# Altium schematic sheet T-Impulse Plus.SchDoc("T-Impulse Plus")
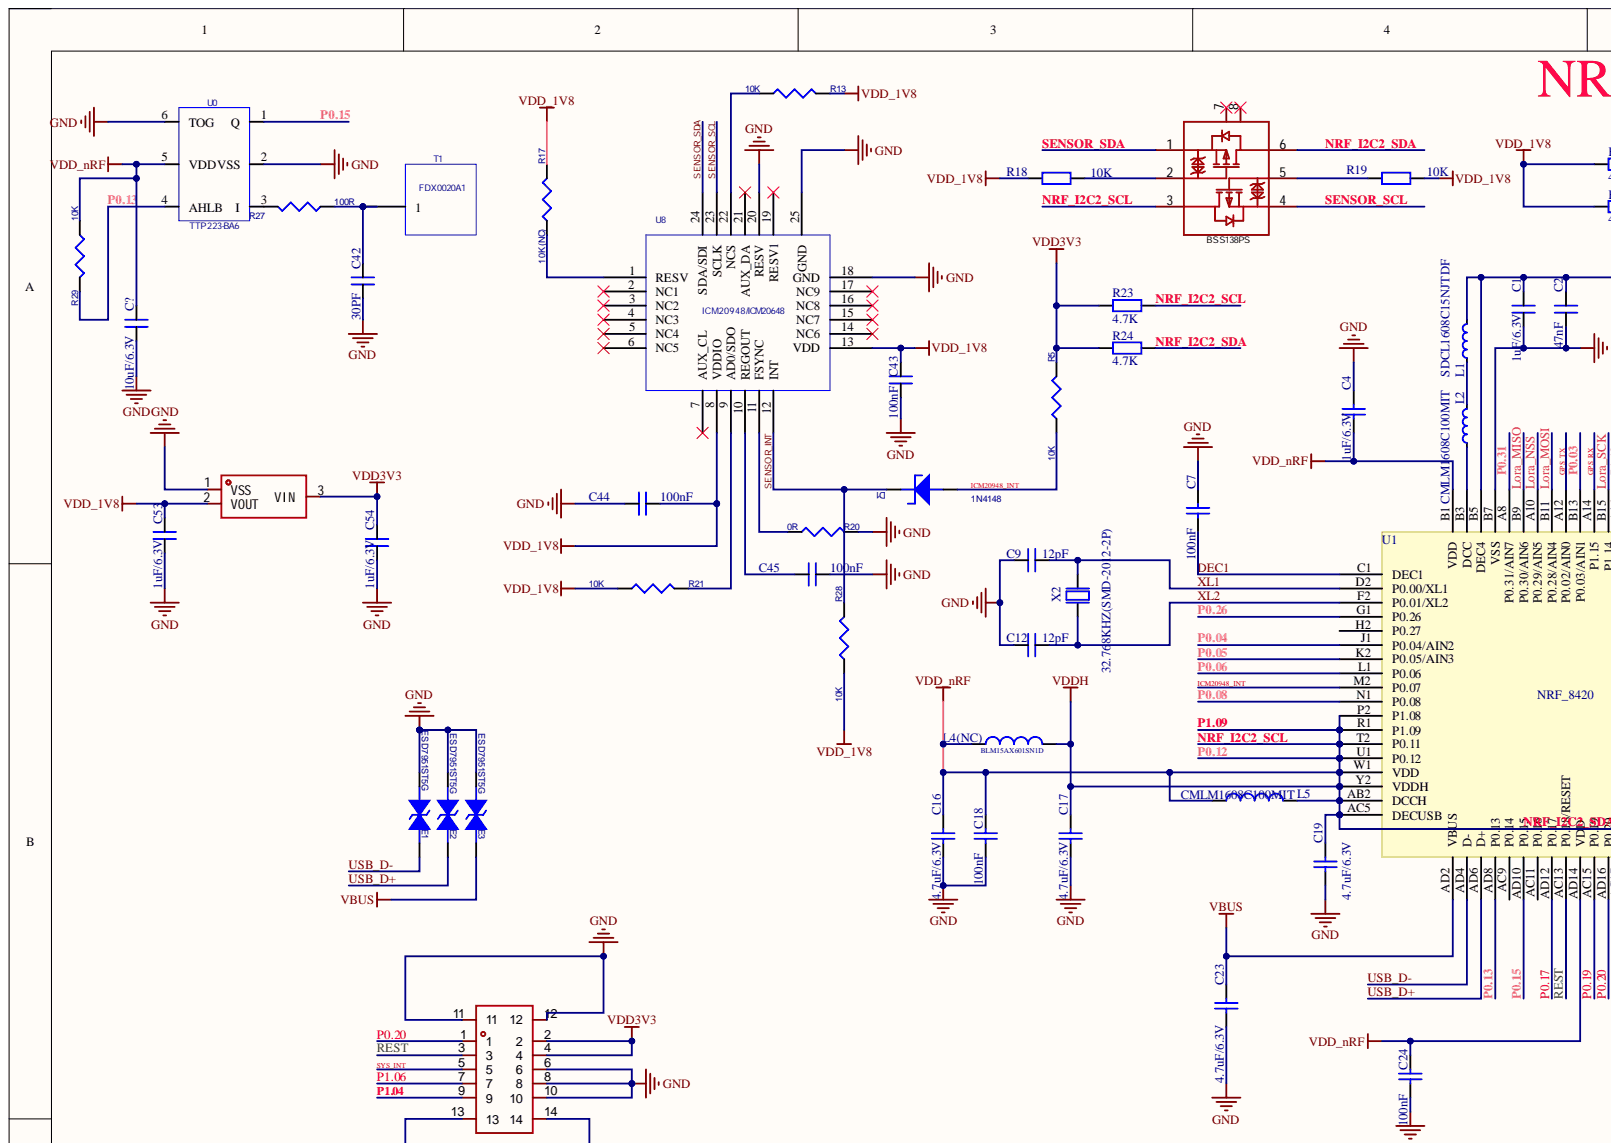
[diagram p0: T-Impulse Plus.SchDoc, top left]
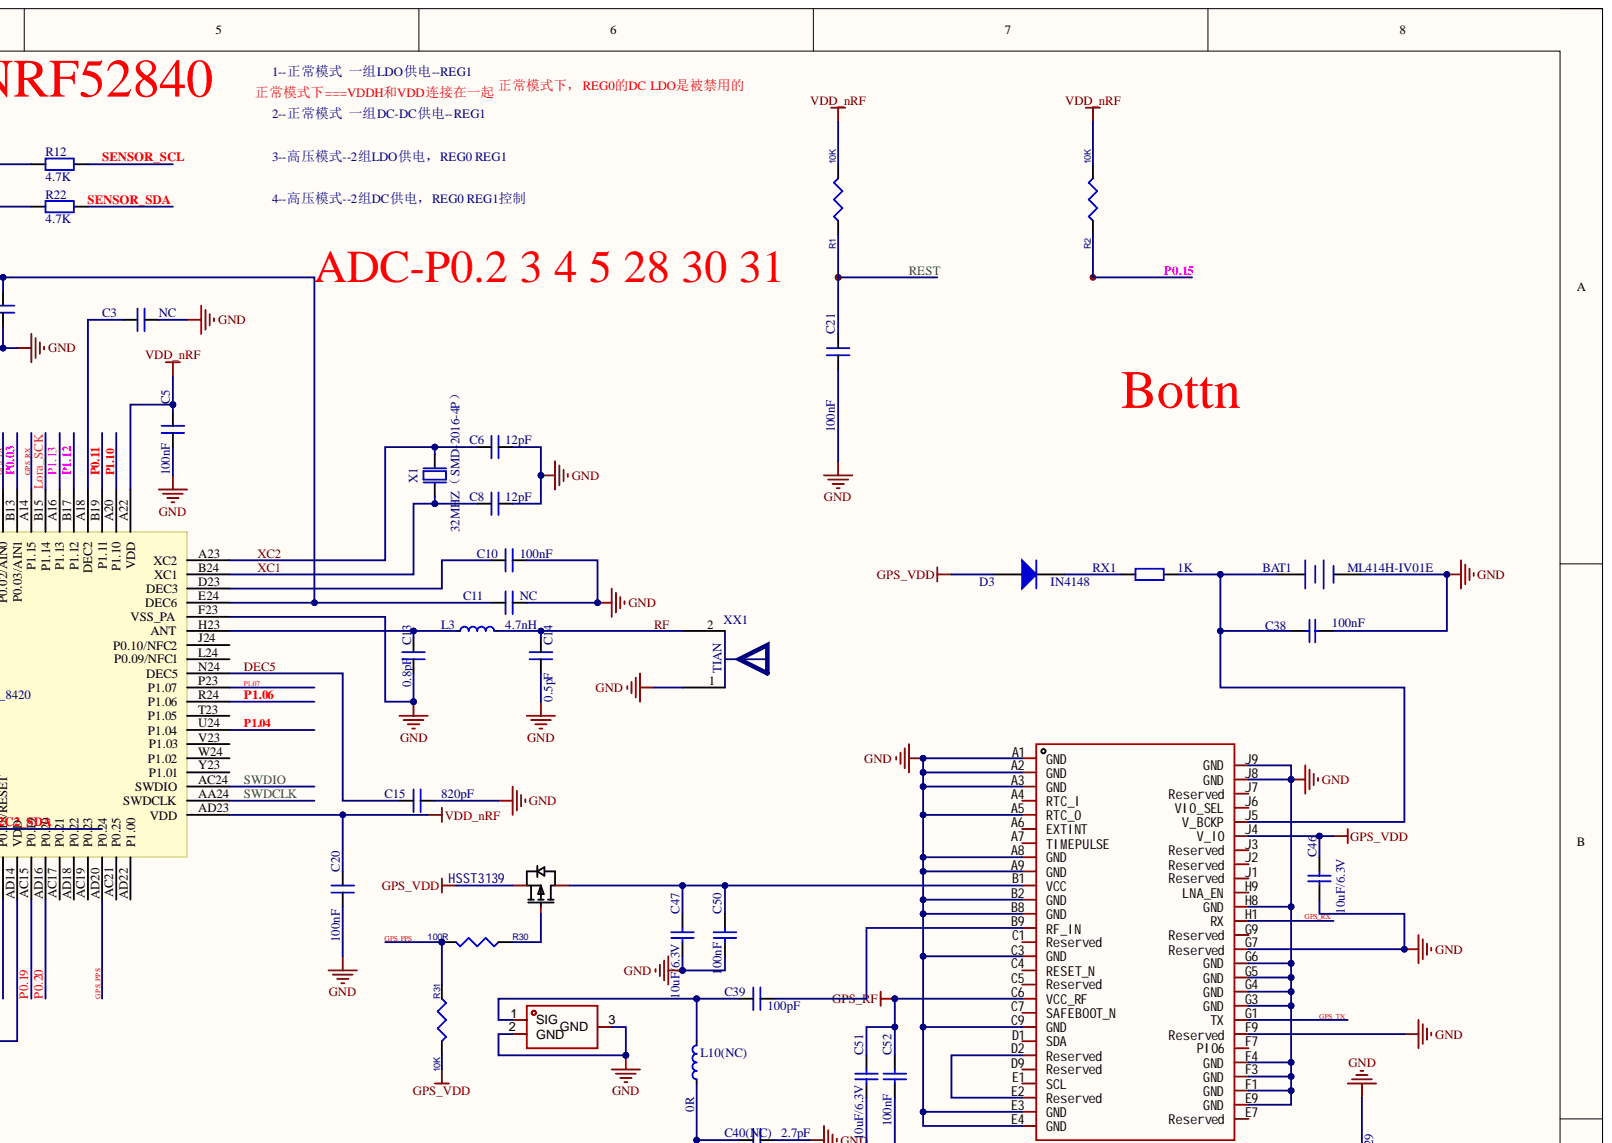
[diagram p1: T-Impulse Plus.SchDoc, top right]
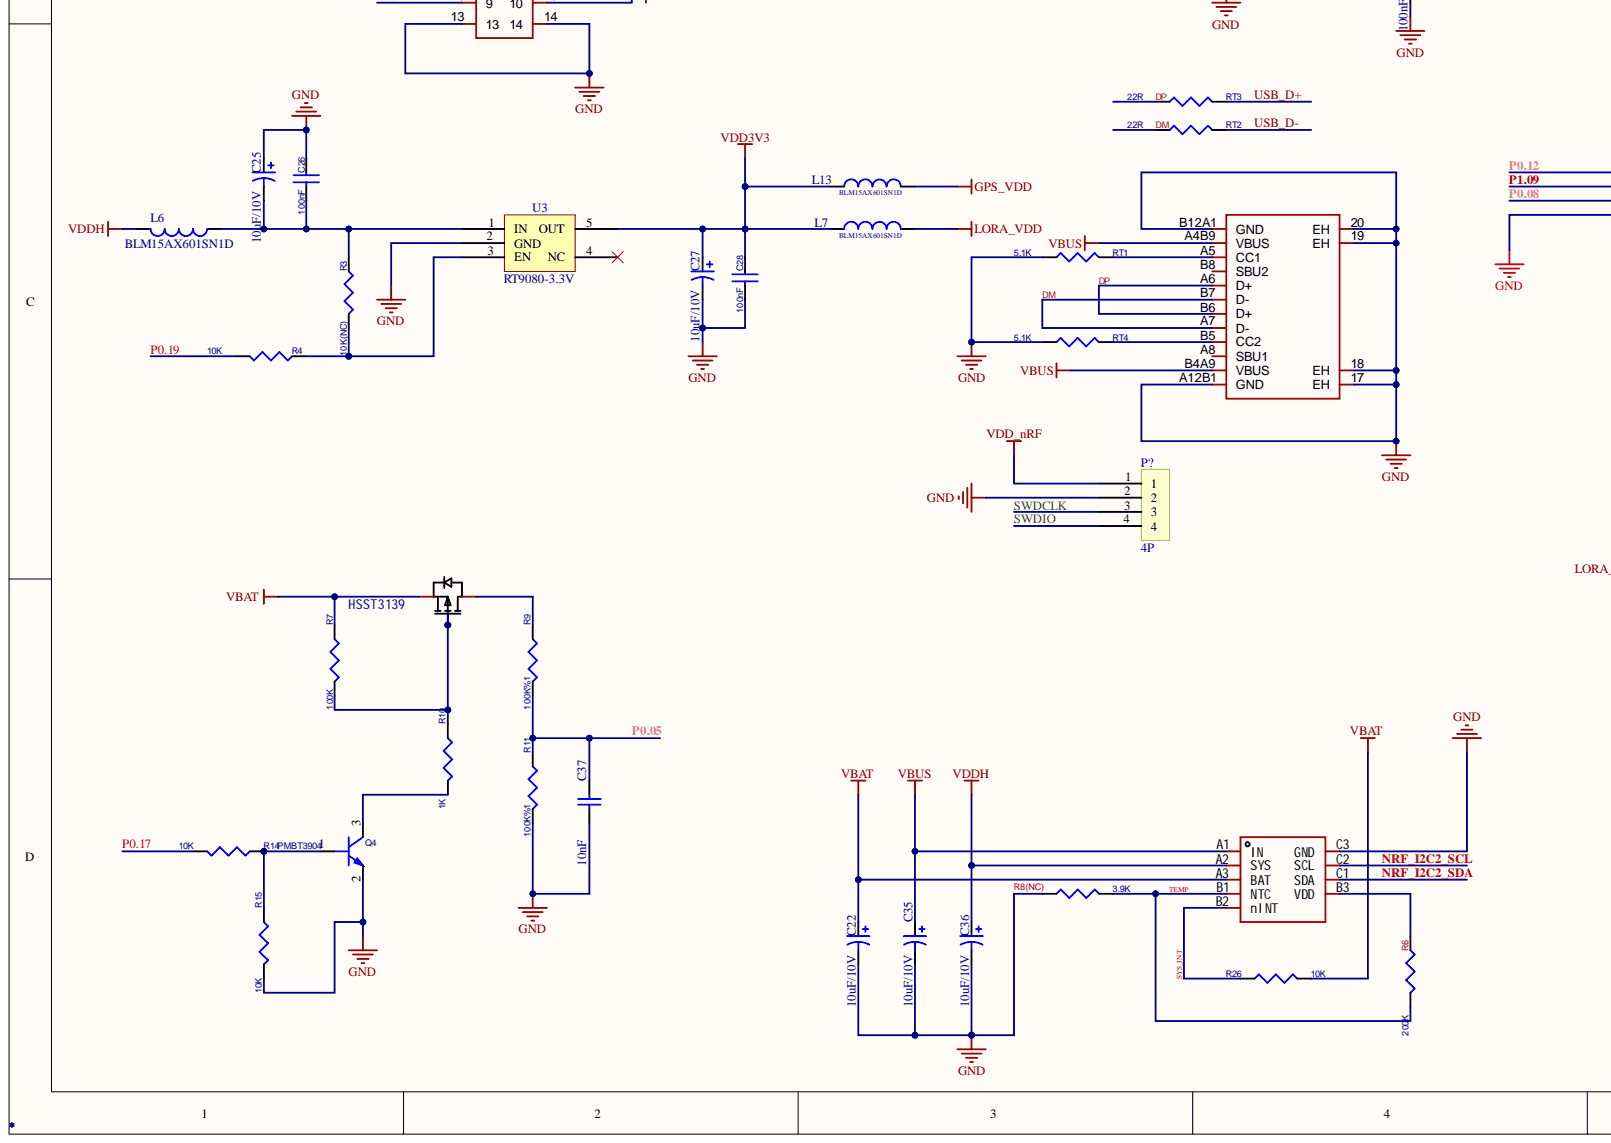
[diagram p2: T-Impulse Plus.SchDoc, bottom left]
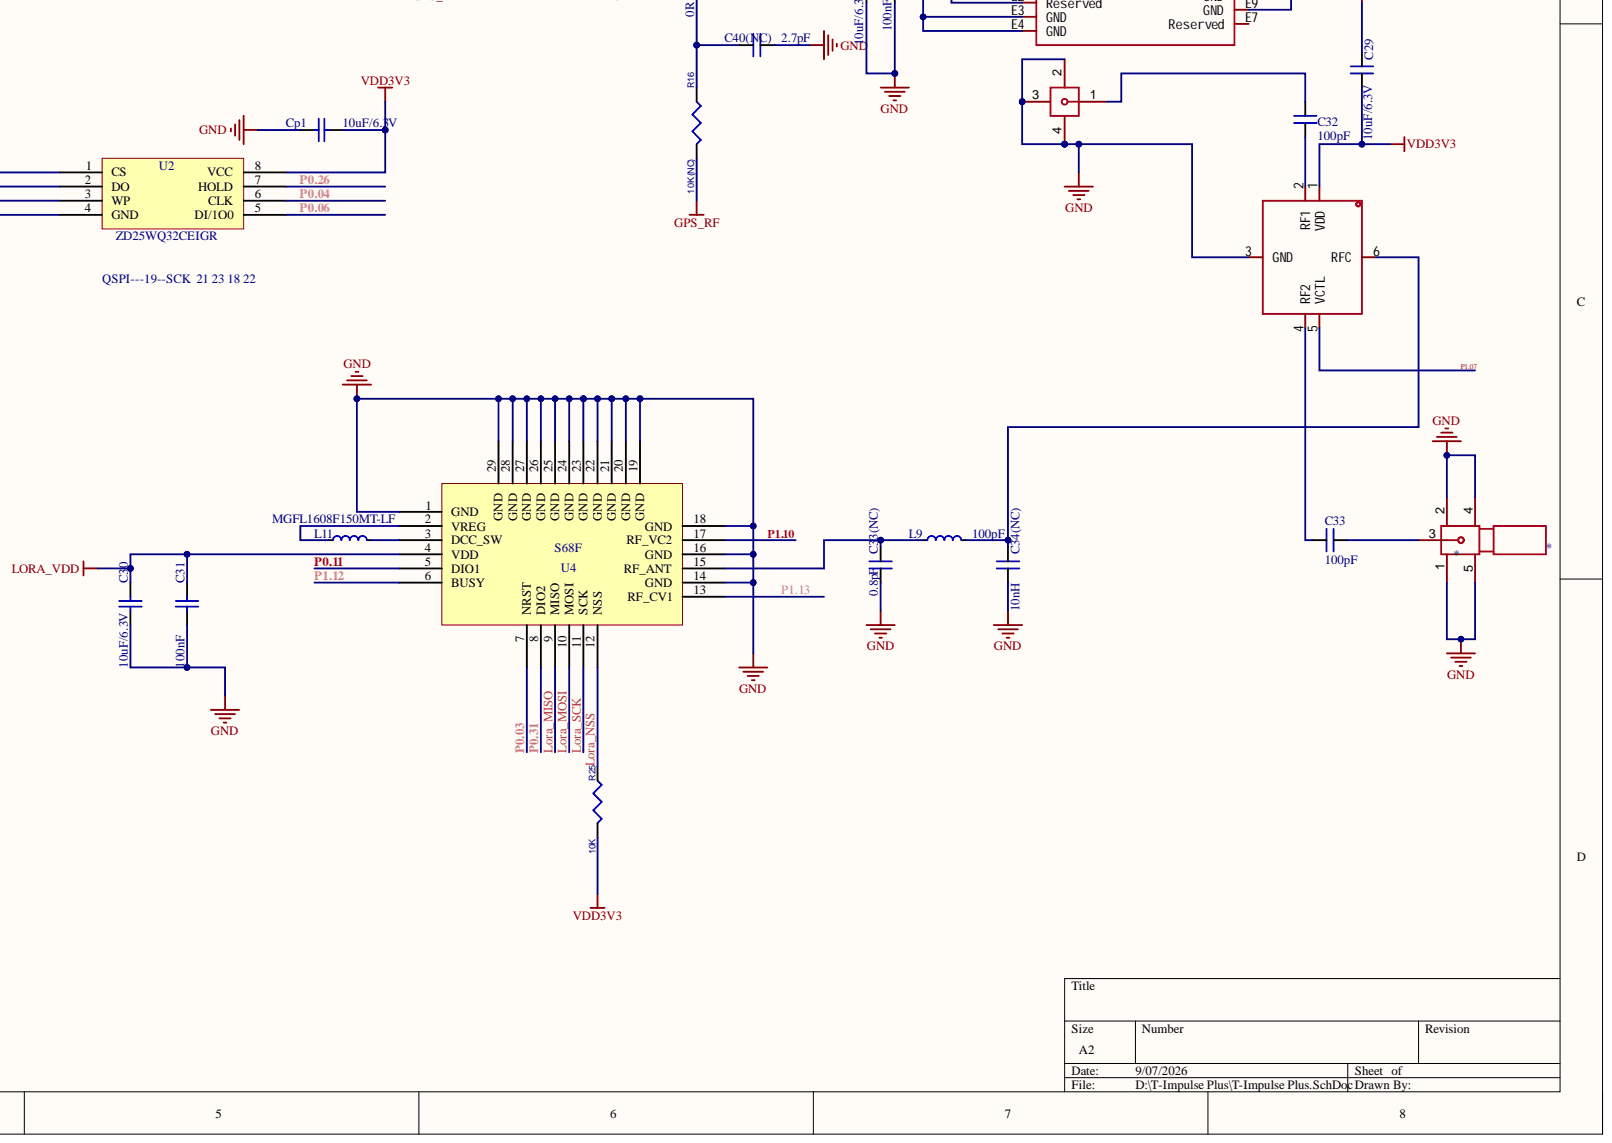
[diagram p3: T-Impulse Plus.SchDoc, bottom right]

## Parts
BAT1  ML414H-IV01E  [BAT-SMD_ML414H-IV01E]
C1  1uF/6.3V  [C0201]
C2  47nF  [C0201]
C3  NC  [C0201]
C4  1uF/6.3V  [C0201]
C5  100nF  [C0201]
C6  12pF  [C0201]
C7  100nF  [C0201]
C8  12pF  [C0201]
C9  12pF  [C0201]
C10  100nF  [C0201]
C11  NC  [C0201]
C12  12pF  [C0201]
C13  0.8pF  [C0201]
C14  0.5pF  [C0201]
C15  820pF  [C0201]
C16  4.7uF/6.3V  [C0201]
C17  4.7uF/6.3V  [C0201]
C18  100nF  [C0201]
C19  4.7uF/6.3V  [C0201]
C20  100nF  [C0201]
C21  100nF  [C0201]
C22  10uF/10V  [LC-0402_C]
C23  4.7uF/6.3V  [C0201]
C24  100nF  [C0201]
C25  10uF/10V  [LC-0402_C]
C26  100nF  [C0201]
C27  10uF/10V  [LC-0402_C]
C28  100nF  [C0201]
C29  10uF/6.3V  [LC-0402_C]
C30  10uF/6.3V  [LC-0402_C]
C31  100nF  [C0201]
C32  100pF  [C0201]
C33  100pF  [C0201]
C33(NC)  0.8pF  [C0201]
C34(NC)  10nH  [C0201]
C35  10uF/10V  [LC-0402_C]
C36  10uF/10V  [LC-0402_C]
C37  10nF  [C0201]
C38  100nF  [C0201]
C39  100pF  [C0201]
C40(NC)  2.7pF  [C0201]
C42  30PF  [C0201]
C43  100nF  [C0201]
C44  100nF  [C0201]
C45  100nF  [C0201]
C46  10uF/6.3V  [LC-0402_C]
C47  10uF/6.3V  [LC-0402_C]
C50  100nF  [C0201]
C51  10uF/6.3V  [LC-0402_C]
C52  100nF  [C0201]
C53  1uF/6.3V  [C0201]
C54  1uF/6.3V  [C0201]
C?  10uF/6.3V  [LC-0402_C]
CN?  BTB0.408-10PLBDR-M41  [CONN-SMD_10P-P0.40_XUNPU_BTB0.408-10PLBDR-M41]  (value not drawn)
Cp1  10uF/6.3V  [LC-0402_C]
D1  1N4148  [SOD-523_L1.2-W0.8-LS1.6-RD]
D3  IN4148  [SOD-323_L1.8-W1.3-LS2.5-RD]
E1  ESD7951ST5G  [DFN1006-2L_L1.0-W0.6-P0.70-BI]
E2  ESD7951ST5G  [DFN1006-2L_L1.0-W0.6-P0.70-BI]
E3  ESD7951ST5G  [DFN1006-2L_L1.0-W0.6-P0.70-BI]
L1  SDCL1608C15NJTDF  [LC-0402_L]
L2  CMLM1608C100MIT  [LC-0603_L]
L3  4.7nH  [L0201]
L4(NC)  BLM15AX601SN1D  [LC-0402_L]
L5  CMLM1608C100MIT  [LC-0603_L]
L6  BLM15AX601SN1D  [LC-0402_L]
L7  BLM15AX601SN1D  [LC-0402_L]
L9  100pF  [L0201]
L10(NC)  0R  [L0201]
L11  MGFL1608F150MT-LF  [LC-0603_L]
L13  BLM15AX601SN1D  [LC-0402_L]
P?  4P  [HDR-TH_4P-P2.54-V]
Q1  HSST3139  [SOT-523-3_L1.6-W0.8-P1.00-LS1.6-BR]
Q2  HSST3139  [SOT-523-3_L1.6-W0.8-P1.00-LS1.6-BR]
Q4  PMBT3904  [SOT-523-3_L1.6-W0.8-P1.00-LS1.6-BR]
R1  10K  [R0201]
R2  10K  [R0201]
R3  10K(NC)  [R0201]
R4  10K  [R0201]
R5  10K  [R0201]
R6  200K  [R0201]
R7  100K  [R0201]
R8(NC)  3.9K  [R0201]
R9  100K%1  [R0201]
R10  1K  [R0201]
R11  100K%1  [R0201]
R12  4.7K  [R0201]
R13  10K  [R0201]
R14  10K  [R0201]
R15  10K  [R0201]
R16  10K(NC)  [R0201]
R17  10K(NC)  [R0201]
R18  10K  [R0201]
R19  10K  [R0201]
R20  0R  [R0201]
R21  10K  [R0201]
R22  4.7K  [R0201]
R23  4.7K  [R0201]
R24  4.7K  [R0201]
R25  10K  [R0201]
R26  10K  [R0201]
R27  100R  [R0201]
R28  10K  [R0201]
R29  10K  [R0201]
R30  100R  [R0201]
R31  10K  [R0201]
RF  BWIPX-1-001E  [IPEX-SMD_4P-L1.7-W1.7-LS2.0_X-RF-3-Z21.25]  (value not drawn)
RF1  (no value)  [SMA-SMD_BWMMCX-JEF]
RF?  ZX-RF-3-Z21.25  [IPEX-SMD_4P-L1.7-W1.7-LS2.0_X-RF-3-Z21.25]  (value not drawn)
RT1  5.1K  [R0201]
RT2  22R  [R0201]
RT3  22R  [R0201]
RT4  5.1K  [R0201]
RX1  1K  [R0201]
T1  FDX0020A1?  value FDX0020A1  [1PIN_PAD]
U0  TTP223  value TTP223-BA6  [SOT26]
U1  NRF_8420  [AQFN50P700X700X85_HS-74]
U2  ZD25WQ32CEIGR  [USON-8_2X3X0.5P]
U3  RT9080-3.3V  [LC-SOT-23-5]
U4  S68F  [S62F]
U6  MIA-M10Q-00B  [LGA-53_L4.5-W4.5-R9-C9-P0.50-TL_MIA-M10Q]  (value not drawn)
U7  BSS138PS  [SOT-23-6_L2.9-W1.6-P0.95-LS2.8-BR]
U8  ICM20948/ICM20648  [QFN24]
U9  XC6206P182MR  [SOT-23-3_L3.0-W1.7-P0.95-LS2.9-BR]  (value not drawn)
U10  SGM41562BXG/TR  [DSBGA-9_L1.5-W1.5-R3-C3-P0.50-BL]  (value not drawn)
U11  SKY13453-385LF  [QFN-6_L1.0-W1.0-P0.50-LS1.0-BL]  (value not drawn)
U?  TYPEC-700-ARP16X7  [TYPE-C-SMD_TYPEC-700-ARP16X711]  (value not drawn)
X1  32MHZ（SMD-2016-4P）  [CRYSTAL-SMD_4P-L2.0-W1.6-BL]
X2  32.768KHZ(SMD-2012-2P)  [CRYSTAL-SMD_L1.7-W1.1]
XX1  TIAN  [0A_C09]

## Nets
GND: BAT1.2 C1.2 C2.2 C3.2 C4.1 C5.1 C6.2 C7.1 C8.2 C9.1 C10.2 C11.2 C12.1 C13.1 C14.1 C15.1 C16.2 C17.2 C18.2 C19.2 C20.2 C21.2 C22.2 C23.2 C24.2 C25.1 C26.1 C27.2 C28.2 C29.2 C30.2 C31.2 C33(NC).1 C34(NC).1 C35.2 C36.2 C37.2 C38.2 C40(NC).2 C42.1 C43.1 C44.1 C45.2 C46.2 C47.2 C50.1 C51.2 C52.2 C53.1 C54.1 C?.1 CN?.6 CN?.8 CN?.10 CN?.11 CN?.12 CN?.13 CN?.14 Cp1.1 E1.2 E2.2 E3.2 P?.2 Q4.2 R8(NC).1 R11.2 R15.2 R20.2 RF.2 RF.3 RF1.1 RF1.2 RF1.4 RF1.5 RF?.2 RF?.3 RF?.4 RT1.1 RT4.1 U0.2(VSS) U0.6(TOG) U1.B7(VSS) U1.F23(VSS_PA) U2.4(GND) U3.2(GND) U4.1(GND) U4.14(GND) U4.16(GND) U4.18(GND) U4.19(GND) U4.20(GND) U4.21(GND) U4.22(GND) U4.23(GND) U4.24(GND) U4.25(GND) U4.26(GND) U4.27(GND) U4.28(GND) U4.29(GND) U6.A1(GND) U6.A2(GND) U6.A3(GND) U6.A5(RTC_O) U6.A8(GND) U6.A9(GND) U6.B2(GND) U6.B8(GND) U6.C3(GND) U6.C9(GND) U6.E3(GND) U6.E4(GND) U6.E9(GND) U6.F1(GND) U6.F3(GND) U6.F4(GND) U6.F9(Reserved) U6.G3(GND) U6.G4(GND) U6.G5(GND) U6.G6(GND) U6.G7(Reserved) U6.H8(GND) U6.J8(GND) U6.J9(GND) U8.18(GND) U8.20(RESV) U8.25(GND) U9.1 U10.C3(GND) U11.3(GND) U?.17(EH) U?.18(EH) U?.19(EH) U?.20(EH) U?.A12B1(GND) U?.B12A1(GND) X1.2 X1.4 XX1.1
GPS_RF: C51.1 C52.1 R16.2 U6.C6(VCC_RF)
GPS_VDD: C46.1 D3.1 L13.2 Q1.2 R31.2 U6.J4(V_IO)
LORA_VDD: C30.1 C31.1 L7.2 U4.4(VDD)
VBAT: C22.1 Q2.2 R7.1 R26.2 U10.A3(BAT)
VBUS: C23.1 C35.1 E3.1 U1.AD2(VBUS) U10.A1(IN) U?.A4B9(VBUS) U?.B4A9(VBUS)
VDD3V3: C27.1 C28.1 C29.1 C54.2 CN?.2 CN?.4 Cp1.2 L7.1 L13.1 R5.2 R23.2 R24.2 R25.2 U2.8(VCC) U3.5(OUT) U9.3 U11.1(VDD)
VDD_1V8: C43.2 C44.2 C53.2 R12.2 R13.2 R17.2 R18.1 R19.2 R21.1 R22.2 R28.1 U8.8(VDDIO) U8.13(VDD) U9.2
DEC1: C7.2 U1.C1(DEC1)
DEC5: C15.2 U1.N24(DEC5)
DM: RT2.1 U?.A7(D-) U?.B7(D-)
DP: RT3.1 U?.A6(D+) U?.B6(D+)
GPS_PPS: R30.2 R31.1 U1.AD20(P0.24)
GPS_RX: U1.A14(P1.15) U6.H1(RX)
GPS_TX: U1.A12(P0.02/AIN0) U6.G1(TX)
ICM20948_INT: D1.1 R5.1 U1.M2(P0.07)
Lora_MISO: U1.B9(P0.30/AIN6) U4.9(MISO)
Lora_MOSI: U1.B11(P0.28/AIN4) U4.10(MOSI)
Lora_NSS: R25.1 U1.A10(P0.29/AIN5) U4.12(NSS)
Lora_SCK: U1.B15(P1.14) U4.11(SCK)
NRF_I2C2_SCL: C4.2 C5.2 C16.1 C17.1 C18.1 C19.1 C20.1 C24.1 C36.1 C?.2 L4(NC).1 L4(NC).2 L5.1 L5.2 L6.2 P?.1 R1.2 R2.2 R23.1 R24.1 R29.1 U0.5(VDD) U1.A22(VDD) U1.AB2(DCCH) U1.AC5(DECUSB) U1.AD14(VDD) U1.AD23(VDD) U1.B1(VDD) U1.P2(P1.08) U1.R1(P1.09) U1.T2(P0.11) U1.U1(P0.12) U1.W1(VDD) U1.Y2(VDDH) U2.1(CS) U2.2(DO) U7.3 U7.6 U10.A2(SYS) U10.C1(SDA) U10.C2(SCL)
P0.03: U1.B13(P0.03/AIN1) U4.7(NRST)
P0.04: U1.J1(P0.04/AIN2) U2.6(CLK)
P0.05: C37.1 R9.2 R11.1 U1.K2(P0.05/AIN3)
P0.06: U1.L1(P0.06) U2.5(DI/1O0)
P0.08: U1.N1(P0.08) U2.3(WP)
P0.11: U1.B19(P1.11) U4.5(DIO1)
P0.13: R29.2 U0.4(AHLB) U1.AD8(P0.13)
P0.15: R2.1 U0.1(Q) U1.AD10(P0.15)
P0.17: R14.2 U1.AD12(P0.17)
P0.19: R4.2 U1.AC15(P0.19)
P0.20: CN?.1 U1.AD16(P0.20)
P0.26: U1.G1(P0.26) U2.7(HOLD)
P0.31: U1.A8(P0.31/AIN7) U4.8(DIO2)
P1.04: CN?.9 U1.U24(P1.04)
P1.06: CN?.7 U1.R24(P1.06)
P1.07: U1.P23(P1.07) U11.5(VCTL)
P1.10: U1.A20(P1.10) U4.17(RF_VC2)
P1.12: U1.B17(P1.12) U4.6(BUSY)
P1.13: U1.A16(P1.13) U4.13(RF_CV1)
REST: C21.1 CN?.3 R1.1 U1.AC13(P0.18/RESET)
RF: C14.2 L3.2 XX1.2
SENSOR_INT: D1.2 R28.2 U8.12(INT)
SENSOR_SCL: R12.1 U7.4 U8.23(SCLK)
SENSOR_SDA: R22.1 U7.1 U8.24(SDA/SDI)
SWDCLK: P?.3 U1.AA24(SWDCLK)
SWDIO: P?.4 U1.AC24(SWDIO)
SYS_INT: CN?.5 R26.1 U10.B2(nINT)
TEMP: R6.2 R8(NC).2 U10.B1(NTC)
USB_D+: E2.1 RT3.2 U1.AD6(D+)
USB_D-: E1.1 RT2.2 U1.AD4(D-)
XC1: C8.1 U1.B24(XC1) X1.1
XC2: C6.1 U1.A23(XC2) X1.3
XL1: C9.2 U1.D2(P0.00/XL1) X2.1
XL2: C12.2 U1.F2(P0.01/XL2) X2.2
NetBAT1_1: BAT1.1 C38.1 RX1.2 U6.J5(V_BCKP)
NetC1_1: C1.1 C2.1 C11.1 L1.2 U1.B5(DEC4) U1.E24(DEC6)
NetC3_1: C3.1 U1.A18(DEC2)
NetC10_1: C10.1 U1.D23(DEC3)
NetC13_2: C13.2 L3.1 U1.H23(ANT)
NetC25_2: C25.2 C26.2 L6.1 R3.1 U3.1(IN)
NetC32_1: C32.1 RF?.1
NetC32_2: C32.2 U11.2(RF1)
NetC33(NC)_2: C33(NC).2 L9.1 U4.15(RF_ANT)
NetC33_1: C33.1 RF1.3
NetC33_2: C33.2 U11.4(RF2)
NetC34(NC)_2: C34(NC).2 L9.2 U11.6(RFC)
NetC39_1: C39.1 L10(NC).2 RF.1
NetC39_2: C39.2 U6.B9(RF_IN)
NetC40(NC)_1: C40(NC).1 L10(NC).1 R16.1
NetC42_2: C42.2 R27.1 T1.1
NetC45_1: C45.1 U8.10(REGOUT)
NetC47_1: C47.1 C50.2 Q1.3 U6.B1(VCC)
NetD3_2: D3.2 RX1.1
NetL1_1: L1.1 L2.2
NetL2_1: L2.1 U1.B3(DCC)
NetL11_1: L11.1 U4.2(VREG)
NetL11_2: L11.2 U4.3(DCC_SW)
NetQ1_1: Q1.1 R30.1
NetQ2_1: Q2.1 R7.2 R10.1
NetQ2_3: Q2.3 R9.1
NetQ4_1: Q4.1 R14.1 R15.1
NetQ4_3: Q4.3 R10.2
NetR3_2: R3.2 R4.1 U3.3(EN)
NetR6_1: R6.1 U10.B3(VDD)
NetR13_1: R13.1 U8.22(NCS)
NetR17_1: R17.1 U8.1(RESV)
NetR18_2: R18.2 U7.2
NetR19_1: R19.1 U7.5
NetR20_1: R20.1 U8.11(FSYNC)
NetR21_2: R21.2 U8.9(AD0/SDO)
NetR27_2: R27.2 U0.3(I)
NetRT1_2: RT1.2 U?.A5(CC1)
NetRT4_2: RT4.2 U?.B5(CC2)
NetU6_D2: U6.D2(Reserved) U6.E2(Reserved)

Not connected: U1 AC9(P0.14) AC11(P0.16) AC17(P0.21) AC19(P0.23) AC21(P0.25) AD18(P0.22) AD22(P1.00) H2(P0.27) J24(P0.10/NFC2) L24(P0.09/NFC1) T23(P1.05) V23(P1.03) W24(P1.02) Y23(P1.01); U3 4(NC); U6 A4(RTC_I) A6(EXTINT) A7(TIMEPULSE) C1(Reserved) C4(RESET_N) C5(Reserved) C7(SAFEBOOT_N) D1(SDA) D9(Reserved) E1(SCL) E7(Reserved) F7(PIO6) G9(Reserved) H9(LNA_EN) J1(Reserved) J2(Reserved) J3(Reserved) J6(VIO_SEL) J7(Reserved); U7 7 8; U8 2(NC1) 3(NC2) 4(NC3) 5(NC4) 6(NC5) 7(AUX_CL) 14(NC6) 15(NC7) 16(NC8) 17(NC9) 19(RESV1) 21(AUX_DA); U? A8(SBU1) B8(SBU2)

## Library data of the parts
BAT1 = Battery: Multicell Battery; Component Kind: Standard; LatestRevisionDate: 17-Jul-2002; LatestRevisionNote: Re-released for DXP Platform.; Library Name: Miscellaneous Devices.IntLib; PackageDescription: Battery; 2 Leads; PackageReference: BAT-2; Published: 24-Mar-1999; Publisher: Altium Limited
C1-C3 C5 C6 C8-C15 C20 C21 C29 C32 C33 C33(NC) C34(NC) C39 C40(NC) C42-C45 C53 C54 C? = C: Component Kind: Standard; Library Name: 光学蓝牙.SCHLIB
C4 C7 C16-C19 C23 C24 C30 C31 C37 C38 C46 C47 C50-C52 Cp1 = Cap: Capacitor; Value: 100pF; Component Kind: Standard; LatestRevisionDate: 17-Jul-2002; LatestRevisionNote: Re-released for DXP Platform.; Library Name: K210--Crazy.SCHLIB; PackageReference: RAD-0.3; Published: 8-Jun-2000; Publisher: Altium Limited
C22 C25 C27 C35 C36 = Cap Pol3: Polarized Capacitor (Surface Mount); Value: 100pF; Component Kind: Standard; LatestRevisionDate: 17-Jul-2002; LatestRevisionNote: Re-released for DXP Platform.; Library Name: Miscellaneous Devices.IntLib; PackageReference: C0805; Published: 8-Jun-2000; Publisher: Altium Limited
C26 C28 = CAP NP: Component Kind: Standard
D1 = DIODE ZENER_4_1: room: power1; Component Kind: Standard; Library Name: 光学蓝牙.SCHLIB
D3 = Diode: Default Diode; Code_JEDEC: DO-214-AB; Component Kind: Standard; LatestRevisionDate: 17-Jul-2002; LatestRevisionNote: Re-released for DXP Platform.; Library Name: MySch.SchLib; PackageDocument: Sep-1996; PackageReference: SMC; Published: 8-Jun-2000; Publisher: Altium Limited
E1-E3 = ESD: Component Kind: Standard
L1-L3 L9 L10(NC) L11 = L0402-3n9: Inductor, 170mA, ?.1nH; Manufacturer: Murata; Manufacturer Part Number: LQP15MN3N9B02D; Part number IPS: 00000007793; Artikkelnr Noca: 33029151; Artikkelnr Simpro: N.A.; Database Table Name: Inductors$; Note: High frequency chip inductor ?%; Value: 3.9nH; Component Kind: Standard; ComponentLink1Description: Datasheet; ComponentLink2Description: Supplier link; Datasheet: http://search.murata.co.jp/Ceramy/image/img/PDF/ENG/L0075S0084LQP15M.pdf; Footprint Doc: 0402; LatestRevisionDate: 2012-09-19; Library Name: Nordic Passive DB.DbLib; Part Number: L0402-3n9; Pin Count: 2; Published: 2009-05-08; Supplier: Digi-Key; Supplier part number: 490-1131-1-ND; Publisher: Nordic Semiconductor
L4(NC) L7 L13 = INDUCTOR: Power Inductor, 4.7uH, 0.7A, 260mR DCR; Manufacturer: Taiyo Yuden; Manufacturer Part Number: CBC2518T4R7M; Part Creator: JG; XMOS Part Number: E-09-0011; Approved: NO; Approx Volume Cost ($): 0.07; DEVICE: L; FORWARD_PCB: 1; LEVEL: STD; Max DC Resistance: 0.26R; Rated Current (Saturation): 0.68A; Rated Current (Temp Rise): 0.92A; Tolerance (%): 20; VALUE: 2.0nH; Component Kind: Standard; Datasheet: http://www.yuden.co.jp/us/product/pdf/ecb2_e.pdf; Digikey Link: http://search.digikey.com/scripts/DkSearch/dksus.dll?lang=en&site=US&keywords=CBC2518T4R7M; Library Name: MySchlib.SchLib; Max Height (mm): 2
L5 L6 = Inductor: Code_IEC: 1005; Value: 10mH; Code_JEITA: 0402; Component Kind: Standard; Description: Inductor; LatestRevisionDate: 29-May-2009; LatestRevisionNote: IPC-7351 Footprint Added.; Library Name: Miscellaneous Devices.IntLib; PackageDescription: Chip Inductor; PackageReference: 0402-A; Published: 8-Jun-2000; Publisher: Altium Limited
Q1 Q2 = AO3401_C727156: Manufacturer: TWGMC(台湾迪嘉); Manufacturer Part: AO3401; Add into BOM: yes; Continuous Drain Current (Id): 4.2A; Drain Source On Resistance (RDS(on)@Vgs,Id): 65mΩ@10V,4.2A; Drain Source Voltage (Vdss): 30V; Gate Threshold Voltage (Vgs(th)@Id): 1.3V@250uA; Power Dissipation (Pd): 350mW; Type: P沟道; Component Kind: Standard; Convert to PCB: yes; Datasheet: https://atta.szlcsc.com/upload/public/pdf/source/20200827/C727156_6E081BBEEFBC6B8FEE164CB196B0AF30.pdf; Device: AO3401_C727156; Input Capacitance (Ciss@Vds): -; JLCPCB Part Class: Extended Part; LCSC Part Name: P沟道 耐压:30V 电流:4.2A; Name: AO3401; Operating Temperature: -; Reverse Transfer Capacitance (Crss@Vds): -; Supplier: LCSC; Supplier Footprint: SOT-23; Supplier Part: C727156; Symbol: AO3401_C727156; Total Gate Charge (Qg@Vgs): -
Q4 = PMBT3904_Dup1: OriginalSymbolOrigin: (0,0); ROOM: power; Component Kind: Standard
R1-R4 R6 R7 R8(NC) R9-R11 R14-R16 R25 R26 R30 R31 = R: Component Kind: Standard; Library Name: 光学蓝牙.SCHLIB
R5 R13 R17 R20 R21 R27-R29 RT1-RT4 = R_Dup1: Component Kind: Standard
R12 R22-R24 = Res2: Resistor; Component Kind: Standard; LatestRevisionDate: 2013年12月24日; LatestRevisionNote: Re-released for DXP Platform.; Library Name: MyLibrary.IntLib; Published: 2013年12月24日; Value: 4.7K
R18 R19 RX1 = Res2: Resistor; Value: 4.7K; Component Kind: Standard; LatestRevisionDate: 2013年12月24日; LatestRevisionNote: Re-released for DXP Platform.; Library Name: MyLibrary.IntLib; Published: 2013年12月24日
RF1 = BWMMCX-JEF: Component Kind: Standard; Symbol: BWMMCX-JEF
T1 = PAD: PCB DECAL: 1PIN_2.54; Source_Path: F:\芯元电子科技\硬件资料\芯元科技\TP4054\LIBRARY1.OLB; Component Kind: Standard
U0 = U_MP3202_1: MP1488/UP6003AMT6  Fixed Frequency White LED Driver; Source_Path: F:\芯元电子科技\硬件资料\芯元科技\手表1.6LCD\T-WATCH-N\T-WATCH-N.DSN; Component Kind: Standard
U2 = GD25LQ128DSIGR: Component Kind: Standard; Library Name: 885阿里云PCB_Project1.SCHLIB
U3 = AP2112K-3.3V: Component Kind: Standard; Library Name: 885阿里云PCB_Project1.SCHLIB
U6 = MIA-M10Q-00B: Manufacturer: U-BLOX; Add into BOM: yes; Component Kind: Standard; Convert to PCB: yes; Datasheet: https://atta.szlcsc.com/upload/public/pdf/source/231023/MIA-M10Q_DataSheet_UBX-22015849-df780d605b9c9d525ff5e8e745ca965b.pdf; Device: MIA-M10Q-00B; JLCPCB Part Class: Extended Part; LCSC Part Name: MIA-M10Q-00B; Manufacturer Part: MIA-M10Q-00B; Supplier: LCSC; Supplier Footprint: SMD-53P; Supplier Part: C6990279; Symbol: MIA-M10Q-00B
U7 = NTLUD3A50PZTBG: Manufacturer: onsemi(安森美); Add into BOM: yes; Component Kind: Standard; Convert to PCB: yes; Datasheet: https://atta.szlcsc.com/upload/public/pdf/source/20221020/883BCF178785C3F963DD958EAC0BBD20.pdf; Device: NTLUD3A50PZTBG; JLCPCB Part Class: Extended Part; LCSC Part Name: 双 P 沟道，?Cool 功率 MOSFET，-20V，-5.6A， 50mΩ; Manufacturer Part: NTLUD3A50PZTBG; Supplier: LCSC; Supplier Footprint: UDFN-6(2x2); Supplier Part: C894018; Symbol: NTLUD3A50PZTBG
U8 = 9250_1: Source_Path: H:\芯元科技\9250\9250.DSN; Component Kind: Standard; PCB DECAL: QFN24; Value: ICM20948/ICM20648
U9 = XC6206P182MR_C347373: Manufacturer: UMW(友台半导体); Add into BOM: yes; Dropout Voltage: 280mV@(50mA); Maximum Input Voltage: 8V; Number of Outputs: 1; Output Current: 250mA; Output Polarity: 正极; Output Type: 固定; Output Voltage: 1.8V; Power Supply Rejection Ratio (PSRR): 40dB@(1kHz); Component Kind: Standard; Convert to PCB: yes; Datasheet: https://atta.szlcsc.com/upload/public/pdf/source/20241029/DFB0998C0588718C146C8B83CE14F55A.pdf; Device: XC6206P182MR_C347373; Feature: 过流保护(OCP)；短路保护; JLCPCB Part Class: Extended Part; LCSC Part Name: 高纹波抑制率、低功耗、低压差 CMOS 降压型电压稳压器; Manufacturer Part: XC6206P182MR; Noise: -; Operating Temperature: -; PCB3D: SOT-23-3L_L2.9-W1.6-H1.1-LS2.8-P0.95; standby current: -; Supplier: LCSC; Supplier Footprint: SOT-23; Supplier Part: C347373; Symbol: XC6206P182MR_C347373
U10 = SGM41562BXG/TR: Manufacturer: SGMICRO(圣邦微); Add into BOM: yes; Component Kind: Standard; Convert to PCB: yes; Datasheet: https://atta.szlcsc.com/upload/public/pdf/source/20230206/50C8D5CD95A193ADAA885C04D891C463.pdf; Device: SGM41562BXG/TR; JLCPCB Part Class: Extended Part; LCSC Part Name: SGM41562BXG/TR; Manufacturer Part: SGM41562BXG/TR; PCB3D: DSBGA-9_L1.5-W1.5-H0.6-R3-C3-P0.50-BL; Supplier: LCSC; Supplier Footprint: WLCSP-9-B(1.52x1.52); Supplier Part: C5153801; Symbol: SGM41562BXG/TR
U11 = SKY13453-385LF: Manufacturer: SKYWORKS; Add into BOM: yes; Frequency range: 10MHz~6GHz; Insertion loss: 0.4dB; isolation: 27dB; Voltage - Supply: 2.5V~3.5V; Component Kind: Standard; Convert to PCB: yes; Datasheet: https://atta.szlcsc.com/upload/public/pdf/source/20180126/C150878_15169561930931309364.pdf; Device: SKY13453-385LF; JLCPCB Part Class: Extended Part; LCSC Part Name: 0.01至6.0GHz单控制SPDT射频开关; Manufacturer Part: SKY13453-385LF; operating temperature: -40℃~+90℃; PCB3D: QFN-6_L1.0-W1.0-H0.5_P0.50; Supplier: LCSC; Supplier Footprint: QFN-6(1x1); Supplier Part: C150878; Symbol: SKY13453-385LF
X1 = XTAL_SMD2016_32MHz_8pF_Kyocera: XTAL SMD 2016, 32MHz, Cl=8pF, Tot: ?0ppm; Manufacturer Part Number: CX2016DB32000D0WZRC1; Part number IPS: 00000007827; Artikkelnr Noca: 22010022; Artikkelnr Simpro: N.A.; Database Table Name: Crystal_Osc$; Note: XTAL SMD 32.000MHz; Component Kind: Standard; Datasheet: http://media.digikey.com/pdf/Data%20Sheets/AVX%20PDFs/CX2016DB32000D0WZRC1_Spec.pdf; Footprint Doc: XTAL_2016; LatestRevisionDate: 2016-06-02; Library Name: Nordic Discrete DB.DbLib; Manufacturer: Kyocera; Part Number: XTAL_SMD2016_32MHz_8pF_Kyocera; Pin Count: 4; Published: 2015-10-15; Supplier: Digi-Key; Supplier part number: 1253-1586-1-ND; Value: 32MHz; Publisher: Nordic Semiconductor
X2 = XTAL_SMD2012_32.768kHz_9pF_MC: XTAL SMD 2012, 32.768kHz, 9pF, ?0ppm; Manufacturer: Micro Crystal; Manufacturer Part Number: CM8V-T1A 32.768KHZ 9PF +/-20PPM TA QC; Part number IPS: 00000007828; Artikkelnr Noca: N.A.; Database Table Name: Crystal_Osc$; Note: XTAL SMD 32.768kHz; Artikkelnr Simpro: N.A.; Component Kind: Standard; Datasheet: http://www.microcrystal.com/images/_PDF/3_Crystal_Ceramic-Package/cm8v-t1a.pdf; Footprint Doc: XTAL_2012; LatestRevisionDate: 2017-01-30; Library Name: Nordic Discrete DB.DbLib; Part Number: XTAL_SMD2012_32.768kHz_9pF_MC; Pin Count: 2; Published: 2016-09-15; Supplier: Allied; Supplier part number: 70417961; Value: 32.768kHz; Publisher: Nordic Semiconductor
XX1 = TIANX: Component Kind: Standard; Library Name: 885阿里云PCB_Project1.SCHLIB
CN? = BTB0.408-10PLBDR-M41: Component Kind: Standard; Symbol: BTB0.408-10PLBDR-M41
P? = 4P: Component Kind: Standard; Library Name: K210--Crazy.SCHLIB
RF = BWIPX-1-001E: Component Kind: Standard; Symbol: BWIPX-1-001E
RF? = ZX-RF-3-Z21.25: Component Kind: Standard; Symbol: ZX-RF-3-Z21.25
U1 = NRF_8420: Component Kind: Standard; Library Name: K210--Crazy.SCHLIB
U4 = S68F: Component Kind: Standard; Library Name: T_Echo_Lite.SCHLIB
U? = TYPEC-700-ARP16X7: Component Kind: Standard; Symbol: TYPEC-700-ARP16X7
